# Altium schematic sheet DL.SchDoc(DL)
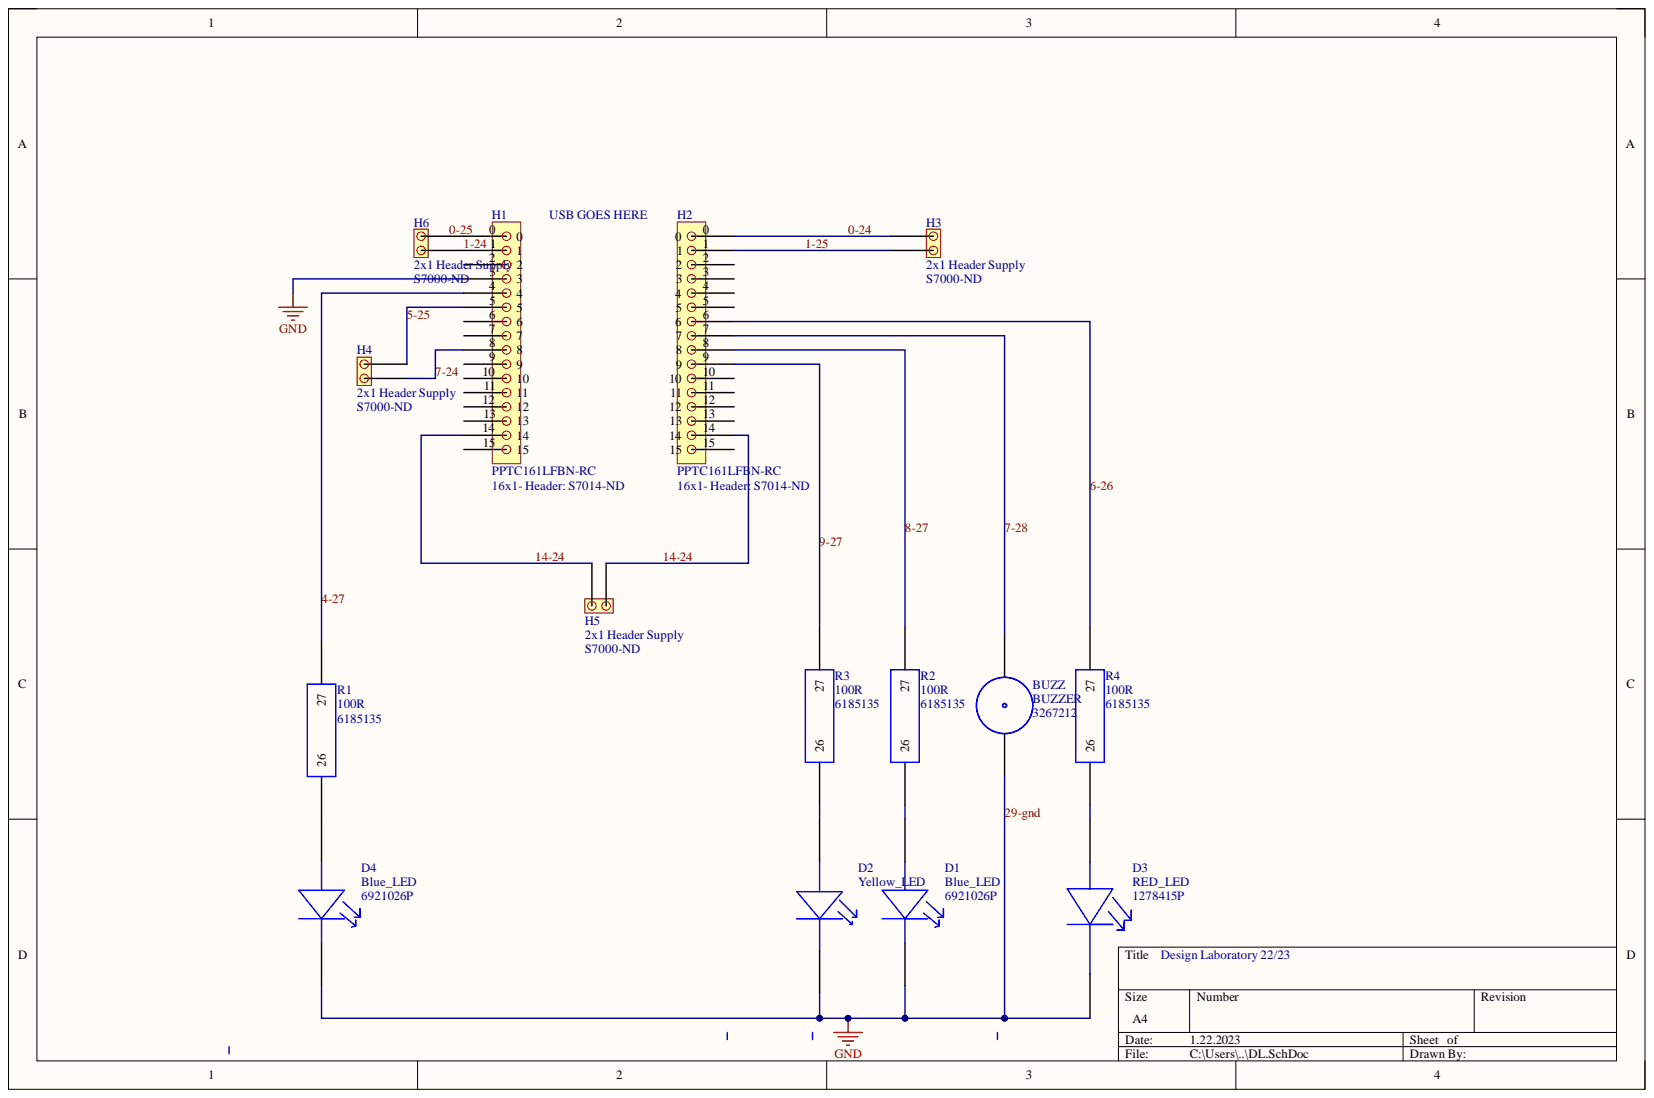
[diagram p0: DL.SchDoc]

## Parts
BUZZ  BUZZER  Supplier Part Number 1: 3267212  [BUZZ]
D1  Blue_LED  Supplier Part Number 1: 6921026P  [LED_BLUE]
D2  Yellow_LED  [LED_Yellow]
D3  RED_LED  Supplier Part Number 1: 1278415P  [LED_GREEN]
D4  Blue_LED  Supplier Part Number 1: 6921026P  [LED_BLUE]
H1  PPTC161LFBN-RC  [DIP32]
H2  PPTC161LFBN-RC  16x1- Header: S7014-ND  [DIP32]
H3  2x1 Header Supply  Connector Type: Header  Supplier Part Number 1: S7000-ND  [Power]
H4  2x1 Header Supply  Connector Type: Header  Supplier Part Number 1: S7000-ND  [Power]
H5  2x1 Header Supply  Connector Type: Header  [Power]
H6  2x1 Header Supply  [Power]
R1  100R  Supplier Part Number 1: 6185135  [R0805]
R2  100R  Supplier Part Number 1: 6185135  [R0805]
R3  100R  Supplier Part Number 1: 6185135  [R0805]
R4  100R  Supplier Part Number 1: 6185135  [R0805]

## Nets
0-24: H2.0 H3.24
1-24: H1.1 H6.24
1-25: H2.1 H3.25
4-27: H1.4 R1.27
5-25: H1.5 H4.25
6-26: H2.6 R4.27
7-24: H1.8 H4.24
7-28: BUZZ.29 H2.7
8-27: H2.8 R2.27
9-27: H2.9 R3.27
14-24: H1.14 H2.14 H5.24 H5.25
29-gnd: BUZZ.28 D1.21 D2.22 D3.18 D4.21 H1.3
NetD1_20: D1.20 R2.26
NetD2_23: D2.23 R3.26
NetD3_19: D3.19 R4.26
NetD4_20: D4.20 R1.26
NetH1_0: H1.0 H6.25
0-25:

Not connected: H1 2 6 7 9 10 11 12 13 15; H2 2 3 4 5 10 11 12 13 15

## Library data of the parts
BUZZ = PS1240P02BT: Audio Buzzer Piezo 30Vo-p 3Vo-p 70dBA Through Hole Pin Ammo; Manufacturer: TDK; Frequency: 4kHz; Number of Terminals/Pins: 2; Operating Supply Voltage: 3V; Washable: No; Category: Audio Products; Component Kind: Standard; ComponentLink1Description: https://datasheet.ciiva.com/0/ef532-ps-112.pdf?src-supplier=Digi-Key; ComponentLink1URL: https://datasheet.ciiva.com/0/ef532-ps-112.pdf?src-supplier=Digi-Key; ComponentLink2Description: https://datasheet.ciiva.com/0/ef532-ps-111.pdf?src-supplier=Digi-Key; ComponentLink2URL: https://datasheet.ciiva.com/0/ef532-ps-111.pdf?src-supplier=Digi-Key; ComponentLink3Description: https://datasheet.ciiva.com/3306/ef532-ps-3306958.pdf?src-supplier=Avnet; ComponentLink3URL: https://datasheet.ciiva.com/3306/ef532-ps-3306958.pdf?src-supplier=Avnet; ComponentLink4Description: https://datasheet.ciiva.com/16225/ef532-ps-16225062.pdf?src-supplier=Onlinecomponents.com; ComponentLink4URL: https://datasheet.ciiva.com/16225/ef532-ps-16225062.pdf?src-supplier=Onlinecomponents.com; Diameter: 12.2mm; Height: 6.5mm; Lead Free: Lead Free; Manufacturer Part Number: PS1240P02BT; Max Operating Temperature: 70°C; Min Operating Temperature: -10°C; Mount: Through Hole; Number of Pins: 2; Package Quantity: 1; Packaging: Tape and Reel; RoHS: Yes; RoHS Compliant: Yes; Series: PS; Stock 1: 1347; Supplier 1: Farnell; Termination: PC Pins; Voltage Rating (DC): 3V; Library Name: DL.SchLib
D1 D4 = LTST-C191TBKT: LED BLUE CLEAR 0603 SMD; Manufacturer: Vishay Lite-On; Dominant Wavelength: 475nm; Forward Current: 20mA; Forward Voltage: 3.8V; Lens Color: Colorless; Lens Style: Diffused,Colorless,Clear,Rectangular; Luminous Intensity: 180mcd; Max Power Dissipation: 75mW; Number of Elements: 1; Power Dissipation: 76mW; Reverse Voltage: 5V; Viewing Angle: 130°; Wavelength: 468nm; Case Code (Imperial): 0603; Case Code (Metric): 1608; Case/Package: 0603; Category: LEDs; Color: Blue; Component Kind: Standard; ComponentLink1Description: https://datasheet.ciiva.com/2110/ltst-c191tbkt-2110256.pdf?src-supplier=Digi-Key; ComponentLink1URL: https://datasheet.ciiva.com/2110/ltst-c191tbkt-2110256.pdf?src-supplier=Digi-Key; ComponentLink2Description: https://datasheet.ciiva.com/19465/2047945-19465673.pdf?src-supplier=Jameco; ComponentLink2URL: https://datasheet.ciiva.com/19465/2047945-19465673.pdf?src-supplier=Jameco; ComponentLink3Description: https://datasheet.ciiva.com/12400/lite-on-ltst-c191tbkt-187207-12400737.pdf?src-supplier=Mouser; ComponentLink3URL: https://datasheet.ciiva.com/12400/lite-on-ltst-c191tbkt-187207-12400737.pdf?src-supplier=Mouser; ComponentLink4Description: https://datasheet.ciiva.com/16444/ltst-c191tbkt-16444756.pdf?src-supplier=Future+Electronics; ComponentLink4URL: https://datasheet.ciiva.com/16444/ltst-c191tbkt-16444756.pdf?src-supplier=Future+Electronics; ComponentLink5Description: https://datasheet.ciiva.com/15117/getdatasheetpartid-133342-15117680.pdf?src-supplier=Verical; ComponentLink5URL: https://datasheet.ciiva.com/15117/getdatasheetpartid-133342-15117680.pdf?src-supplier=Verical; ComponentLink6Description: https://datasheet.ciiva.com/11774/0900766b80dc02b4-11774413.pdf?src-supplier=Rs; ComponentLink6URL: https://datasheet.ciiva.com/11774/0900766b80dc02b4-11774413.pdf?src-supplier=Rs; ComponentLink7Description: https://datasheet.ciiva.com/2110/s-110-ltst-c191tbkt-2110257.pdf?src-supplier=Digi-Key; ComponentLink7URL: https://datasheet.ciiva.com/2110/s-110-ltst-c191tbkt-2110257.pdf?src-supplier=Digi-Key; Depth: 1.6mm; Height: 0.55mm; Illumination Color: Blue; Lead Free: Lead Free; Length: 0.8mm; Lens Transparency: Clear; Manufacturer Part Number: LTST-C191TBKT; Max Operating Temperature: 80°C; Min Operating Temperature: -20°C; Mount: Surface Mount; Number of LEDs: 1; Number of Pins: 2; Package Quantity: 1; Packaging: Tape and Reel; Radiation Hardening: No; RoHS: Yes; RoHS Compliant: Yes; Stock 1: 15050; Supplier 1: Rs; Test Current: 20mA; Width: 0.8mm; Library Name: DL.SchLib
D2 = LTST-C191KSKT: LED YELLOW CLEAR 0603 SMD; Manufacturer: Vishay Lite-On; Dominant Wavelength: 597nm; Forward Current: 30mA; Forward Voltage: 2.4V; Lens Color: Colorless; Lens Style: Diffused,Colorless,Rectangular,Clear; Luminous Intensity: 180mcd; Number of Elements: 1; Power Dissipation: 75mW; Reverse Voltage: 5V; Test Current: 20mA; Viewing Angle: 130°; Wavelength: 588nm; Case Code (Imperial): 0603; Case Code (Metric): 1608; Case/Package: 0603; Category: LEDs; Color: Yellow; Component Kind: Standard; ComponentLink1Description: https://datasheet.ciiva.com/12400/lite-on-ltst-c191kskt-190097-12400797.pdf?src-supplier=Mouser; ComponentLink1URL: https://datasheet.ciiva.com/12400/lite-on-ltst-c191kskt-190097-12400797.pdf?src-supplier=Mouser; ComponentLink2Description: https://datasheet.ciiva.com/16213/lite-on20ltst-c191kskt-16213725.pdf?src-supplier=Future+Electronics; ComponentLink2URL: https://datasheet.ciiva.com/16213/lite-on20ltst-c191kskt-16213725.pdf?src-supplier=Future+Electronics; ComponentLink3Description: https://datasheet.ciiva.com/15117/getdatasheetpartid-141495-15117732.pdf?src-supplier=Verical; ComponentLink3URL: https://datasheet.ciiva.com/15117/getdatasheetpartid-141495-15117732.pdf?src-supplier=Verical; ComponentLink4Description: https://datasheet.ciiva.com/11773/0900766b80dc02b3-11773512.pdf?src-supplier=Rs; ComponentLink4URL: https://datasheet.ciiva.com/11773/0900766b80dc02b3-11773512.pdf?src-supplier=Rs; ComponentLink5Description: https://datasheet.ciiva.com/2109/s-110-ltst-c191kskt-2109984.pdf?src-supplier=Digi-Key; ComponentLink5URL: https://datasheet.ciiva.com/2109/s-110-ltst-c191kskt-2109984.pdf?src-supplier=Digi-Key; Depth: 0.8mm; Height: 0.55mm; Illumination Color: Yellow; Lead Free: Lead Free; Length: 1.6mm; Lens Transparency: Clear; Manufacturer Part Number: LTST-C191KSKT; Max Operating Temperature: 85°C; Min Operating Temperature: -55°C; Mount: Surface Mount; Number of LEDs: 1; Number of Pins: 2; Package Quantity: 1; Packaging: Tape and Reel; Power Rating: 75mW; Radiation Hardening: No; RoHS: Yes; RoHS Compliant: Yes; Stock 1: 14800; Supplier 1: Rs; Supplier Part Number 1: 6921017P; Width: 0.8mm; Library Name: DL.SchLib
D3 = LTST-C191KRKT: LED Uni-Color Red 639nm 2-Pin Chip LED T/R / LED RED CLEAR 0603 SMD; Manufacturer: Vishay Lite-On; Dominant Wavelength: 631nm; Forward Current: 30mA; Forward Voltage: 2V; Lens Color: Colorless; Lens Style: Clear,Diffused,Colorless,Rectangular; Luminous Intensity: 54mcd; Number of Elements: 1; Power Dissipation: 75mW; Reverse Voltage: 5V; Test Current: 20mA; Viewing Angle: 130°; Case Code (Imperial): 0603; Case Code (Metric): 1608; Case/Package: 0603; Category: LEDs; Color: Red; Component Kind: Standard; ComponentLink1Description: https://datasheet.ciiva.com/12400/lite-on-ltst-c191krkt-190135-12400910.pdf?src-supplier=Mouser; ComponentLink1URL: https://datasheet.ciiva.com/12400/lite-on-ltst-c191krkt-190135-12400910.pdf?src-supplier=Mouser; ComponentLink2Description: https://datasheet.ciiva.com/16212/ltst-c191krkt-16212419.pdf?src-supplier=Future+Electronics; ComponentLink2URL: https://datasheet.ciiva.com/16212/ltst-c191krkt-16212419.pdf?src-supplier=Future+Electronics; ComponentLink3Description: https://datasheet.ciiva.com/15117/getdatasheetpartid-141922-15117737.pdf?src-supplier=Verical; ComponentLink3URL: https://datasheet.ciiva.com/15117/getdatasheetpartid-141922-15117737.pdf?src-supplier=Verical; ComponentLink4Description: https://datasheet.ciiva.com/2109/s-110-ltst-c191krkt-2109971.pdf?src-supplier=Digi-Key; ComponentLink4URL: https://datasheet.ciiva.com/2109/s-110-ltst-c191krkt-2109971.pdf?src-supplier=Digi-Key; ComponentLink5Description: https://datasheet.ciiva.com/2109/s-110-ltst-c191krkt-2109970.pdf?src-supplier=Digi-Key; ComponentLink5URL: https://datasheet.ciiva.com/2109/s-110-ltst-c191krkt-2109970.pdf?src-supplier=Digi-Key; ComponentLink6Description: https://datasheet.ciiva.com/2109/s-110-ltst-c191krkt-2109972.pdf?src-supplier=Digi-Key; ComponentLink6URL: https://datasheet.ciiva.com/2109/s-110-ltst-c191krkt-2109972.pdf?src-supplier=Digi-Key; Depth: 0.8mm; Height: 0.55mm; Illumination Color: Red; Lead Free: Lead Free; Length: 1.6mm; Lens Transparency: Clear; Manufacturer Part Number: LTST-C191KRKT; Max Operating Temperature: 85°C; Min Operating Temperature: -55°C; Mount: Surface Mount; Number of LEDs: 1; Number of Pins: 2; Package Quantity: 1; Packaging: Tape and Reel; Power Rating: 75mW; Radiation Hardening: No; RoHS: Yes; RoHS Compliant: Yes; Stock 1: 84900; Supplier 1: Rs; Voltage: 2V; Wavelength: 639nm; Width: 0.8mm; Library Name: DL.SchLib
H1 = PPTC161LFBN-RC: Sullins Connector Solutions PPTC161LFBN-RC / Conn Header Female 16POS .1"" T; 16x1- Header: S7014-ND; Category: Connectors; Component Kind: Standard; ComponentLink1Description: https://media.digikey.com/pdf/Data%20Sheets/Sullins%20PDFs/Female_Headers.100_DS.pdf?src-supplier=Digi-Key; ComponentLink1URL: https://media.digikey.com/pdf/Data%20Sheets/Sullins%20PDFs/Female_Headers.100_DS.pdf?src-supplier=Digi-Key; ComponentLink2Description: http://www.sullinscorp.com/catalogs/101_Page80-81_HEADER_.100_2.54mm_FEMALE.pdf?src-supplier=Future+Electronics; ComponentLink2URL: http://www.sullinscorp.com/catalogs/101_Page80-81_HEADER_.100_2.54mm_FEMALE.pdf?src-supplier=Future+Electronics; Manufacturer: Sullins; Manufacturer Part Number: PPTC161LFBN-RC; Pricing 1: 1=0,98000, 10=0,82900, 80=0,74450, 440=0,60911, 1000=0,54144, 5000=0,48880 (USD); RoHS: Yes; Stock 1: 2034; Supplier 1: Digi-Key; Library Name: DL.SchLib
H2 = PPTC161LFBN-RC: Sullins Connector Solutions PPTC161LFBN-RC / Conn Header Female 16POS .1"" T; Category: Connectors; Component Kind: Standard; ComponentLink1Description: https://media.digikey.com/pdf/Data%20Sheets/Sullins%20PDFs/Female_Headers.100_DS.pdf?src-supplier=Digi-Key; ComponentLink1URL: https://media.digikey.com/pdf/Data%20Sheets/Sullins%20PDFs/Female_Headers.100_DS.pdf?src-supplier=Digi-Key; ComponentLink2Description: http://www.sullinscorp.com/catalogs/101_Page80-81_HEADER_.100_2.54mm_FEMALE.pdf?src-supplier=Future+Electronics; ComponentLink2URL: http://www.sullinscorp.com/catalogs/101_Page80-81_HEADER_.100_2.54mm_FEMALE.pdf?src-supplier=Future+Electronics; Manufacturer: Sullins; Manufacturer Part Number: PPTC161LFBN-RC; Pricing 1: 1=0,98000, 10=0,82900, 80=0,74450, 440=0,60911, 1000=0,54144, 5000=0,48880 (USD); RoHS: Yes; Stock 1: 2034; Supplier 1: Digi-Key; Library Name: DL.SchLib
H3-H6 = PPTC021LFBN-RC: Female, 2C, Straight, .100"CC; 3.20mm Tail, No Mt. 100u" Tin; PBT, Phos Bronze, 105 C; Manufacturer: Sullins; Number of Positions: 2; Pitch: 0.1inch; Category: Connectors; Color: Black; Component Kind: Standard; ComponentLink1Description: https://media.digikey.com/pdf/Data%20Sheets/Sullins%20PDFs/Female_Headers.100_DS.pdf?src-supplier=Digi-Key; ComponentLink1URL: https://media.digikey.com/pdf/Data%20Sheets/Sullins%20PDFs/Female_Headers.100_DS.pdf?src-supplier=Digi-Key; ComponentLink2Description: http://www.sullinscorp.com/catalogs/78_Page80-81_HEADER_.100_2.54mm_FEMALE.pdf?src-supplier=Future+Electronics; ComponentLink2URL: http://www.sullinscorp.com/catalogs/78_Page80-81_HEADER_.100_2.54mm_FEMALE.pdf?src-supplier=Future+Electronics; Contact Material: Bronze; Contact Plating: Tin; Contact Type: Female Socket; Height: 8.5mm; Housing Material: Plastic; Lead Free: Lead Free; Length: 5.58mm; Manufacturer Part Number: PPTC021LFBN-RC; Mount: Through Hole; Number of Rows: 1; Package Quantity: 1000; Packaging: Bulk; Pricing 1: 1=0,32000, 10=0,27500, 100=0,21390, 500=0,18332, 1000=0,15278, 5000=0,13095, 10000=0,12610 (USD); RoHS: Yes; RoHS Compliant: Yes; Stock 1: 46704; Supplier 1: Digi-Key; Termination: Solder; Width: 2.5mm; Library Name: DL.SchLib
  H5: Supplier Part Number 1: S7000-ND
  H6: Connector Type: Header; Supplier Part Number 1: S7000-ND
R1-R4 = RC0805FR-07100RL: Res Thick Film 0805 100 Ohm 1% 1/8W ±100ppm/°C Molded SMD Paper T/R; Manufacturer: Yageo; Application(s): HIGH RELIABILITY; Max Power Dissipation: 125mW; Voltage Rating: 150V; Case Code (Imperial): 0805; Case Code (Metric): 2012; Case/Package: 0805; Category: Chip SMD Resistors; Component Kind: Standard; ComponentLink1Description: https://datasheet.ciiva.com/7941/1697719-7941680.pdf?src-supplier=Element14; ComponentLink1URL: https://datasheet.ciiva.com/7941/1697719-7941680.pdf?src-supplier=Element14; ComponentLink2Description: https://datasheet.ciiva.com/11808/0900766b8106beab-11808877.pdf?src-supplier=Rs; ComponentLink2URL: https://datasheet.ciiva.com/11808/0900766b8106beab-11808877.pdf?src-supplier=Rs; ComponentLink3Description: https://datasheet.ciiva.com/7660/38627-7660797.pdf?src-supplier=Element14; ComponentLink3URL: https://datasheet.ciiva.com/7660/38627-7660797.pdf?src-supplier=Element14; ComponentLink4Description: https://datasheet.ciiva.com/2456/pyu-r-int-thick-7-2456987.pdf?src-supplier=Digi-Key; ComponentLink4URL: https://datasheet.ciiva.com/2456/pyu-r-int-thick-7-2456987.pdf?src-supplier=Digi-Key; ComponentLink5Description: https://datasheet.ciiva.com/17082/rc0805-17082697.pdf?src-supplier=Future+Electronics; ComponentLink5URL: https://datasheet.ciiva.com/17082/rc0805-17082697.pdf?src-supplier=Future+Electronics; ComponentLink6Description: https://datasheet.ciiva.com/7941/1686128-7941679.pdf?src-supplier=Element14; ComponentLink6URL: https://datasheet.ciiva.com/7941/1686128-7941679.pdf?src-supplier=Element14; ComponentLink7Description: https://datasheet.ciiva.com/22747/444164-22747535.pdf?src-supplier=Element14; ComponentLink7URL: https://datasheet.ciiva.com/22747/444164-22747535.pdf?src-supplier=Element14; ComponentLink8Description: https://datasheet.ciiva.com/46447/444164-46447562.pdf?src-supplier=Element14; ComponentLink8URL: https://datasheet.ciiva.com/46447/444164-46447562.pdf?src-supplier=Element14; ComponentLink9Description: https://datasheet.ciiva.com/2456/pyu-r-int-thick-7-2456988.pdf?src-supplier=Digi-Key; ComponentLink9URL: https://datasheet.ciiva.com/2456/pyu-r-int-thick-7-2456988.pdf?src-supplier=Digi-Key; ComponentLink10Description: https://datasheet.ciiva.com/2456/pyu-r-int-thick-7-2456989.pdf?src-supplier=Digi-Key; ComponentLink10URL: https://datasheet.ciiva.com/2456/pyu-r-int-thick-7-2456989.pdf?src-supplier=Digi-Key; Depth: 1.25mm; Features: Moisture Resistant; Height: 0.5mm; Lead Free: Lead Free; Lead Pitch: 0.4mm; Length: 2mm; Manufacturer Part Number: RC0805FR-07100RL; Max Operating Temperature: 155°C; Military Standard: Not; Min Operating Temperature: -55°C; Number of Pins: 2; Number of Terminations: 2; Package Quantity: 5000; Packaging: Cut Tape; Power Rating: 125mW; Resistance: 100R; Resistor Type: Thick Film; RoHS: Yes; RoHS Compliant: Yes; Series: RC; Stock 1: 90000; Supplier 1: Rs; Technology: THICK FILM; Temperature Coefficient: 100ppm/°C; Termination: SMD/SMT; Tolerance: 1%; Type: General Purpose; Voltage Rating (DC): 150V; Weight: 0.000917oz; Width: 1.25mm; Library Name: DL.SchLib
